# Altium schematic sheet Sheet1.SchDoc(Sheet1)
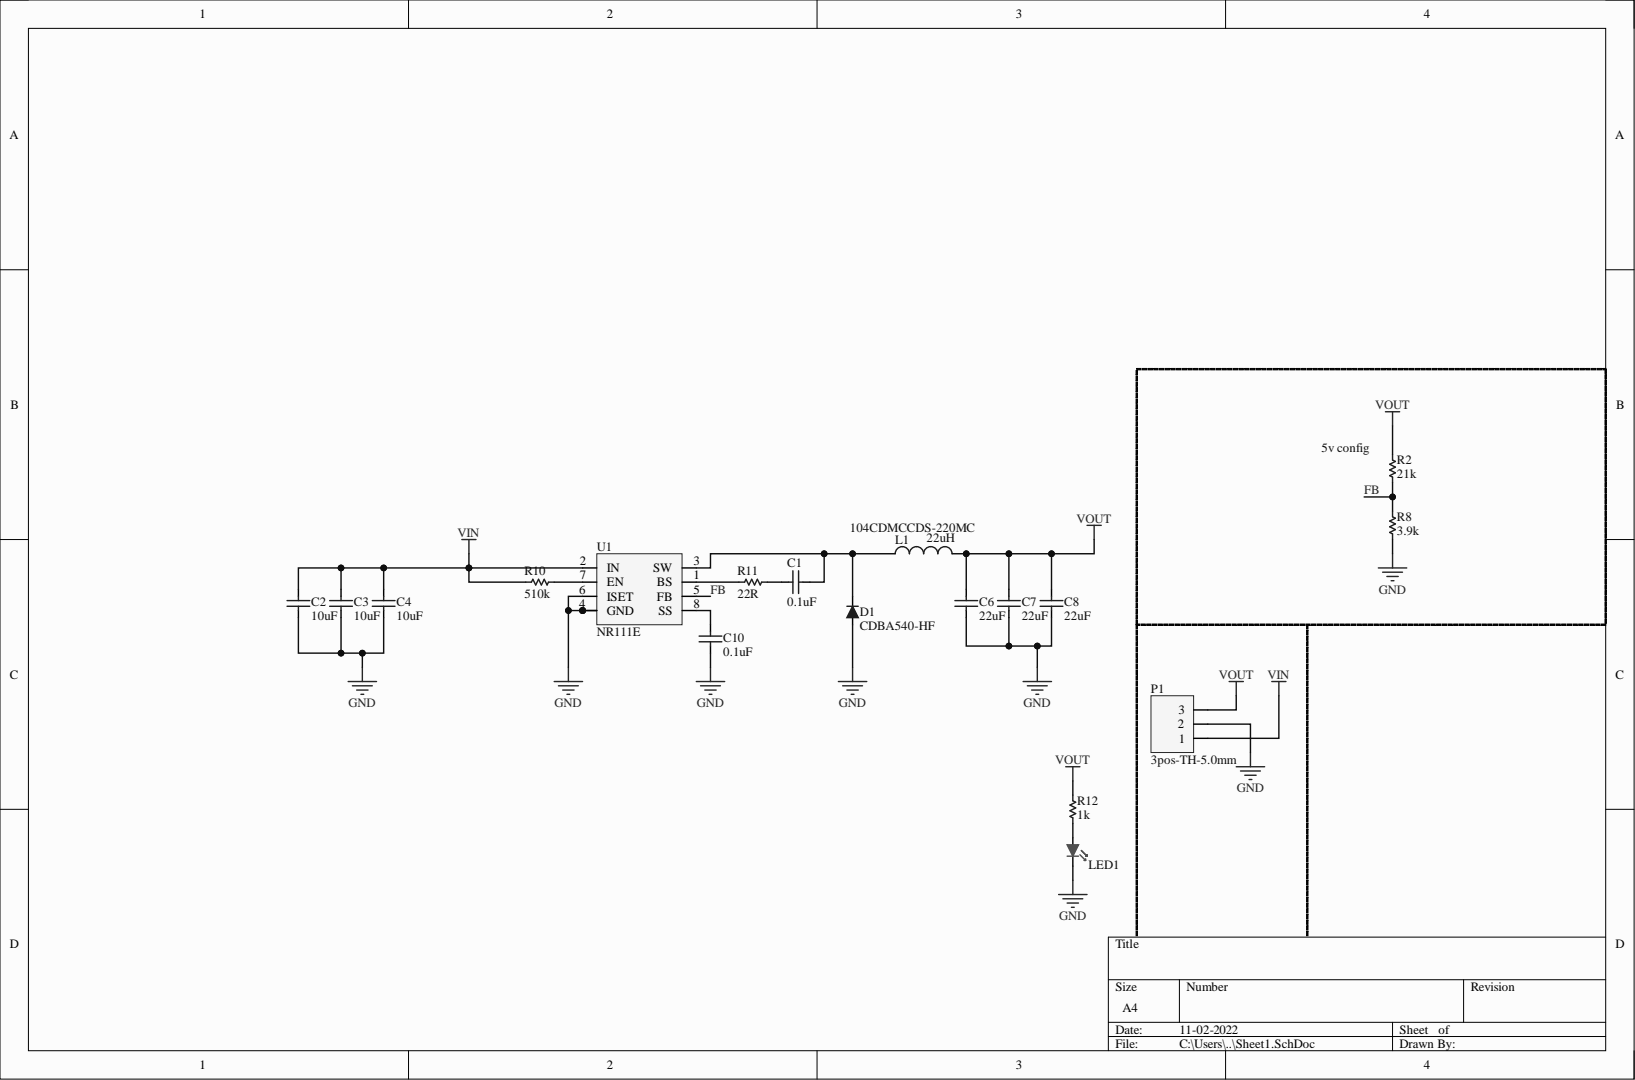
[diagram p0: Sheet1.SchDoc]

## Parts
C1  value 0.1uF  [CAPACITOR-0603]  (hidden comment: Capacitor-0603-0.1uF-50V-X7R)
C2  value 10uF  [CAPACITOR-0805]  (hidden comment: Capacitor-0805-10uF-35V-X5R)
C3  value 10uF  [CAPACITOR-0805]  (hidden comment: Capacitor-0805-10uF-35V-X5R)
C4  value 10uF  [CAPACITOR-0805]  (hidden comment: Capacitor-0805-10uF-35V-X5R)
C6  value 22uF  [CAPACITOR-0805]  (hidden comment: Capacitor-0805-22uF-16V-X5R)
C7  value 22uF  [CAPACITOR-0805]  (hidden comment: Capacitor-0805-22uF-16V-X5R)
C8  value 22uF  [CAPACITOR-0805]  (hidden comment: Capacitor-0805-22uF-16V-X5R)
C10  value 0.1uF  [CAPACITOR-0603]  (hidden comment: Capacitor-0603-0.1uF-50V-X7R)
D1  CDBA540-HF  [SMA/DO-214AC]
L1  104CDMCCDS-220MC  value 22uH  [Inductor_22UH 5A]
LED1  B1911USD-20D000114U1930  [LED-0603-2PIN]  (value not drawn)
P1  3pos-TH-5.0mm  [Side Connector 3pins]
R2  value 21k  [Resistor-0603]  (hidden comment: SMT resistor)
R8  value 3.9k  [Resistor-0603]  (hidden comment: SMT resistor)
R10  value 510k  [Resistor-0603]  (hidden comment: SMT resistor)
R11  value 22R  [Resistor-0603]  (hidden comment: SMT resistor)
R12  value 1k  [Resistor-0603]  (hidden comment: SMT resistor)
U1  NR111E  [SOIC-8-EXPOSED-PAD]

## Nets
GND: C2.1 C3.1 C4.1 C6.1 C7.1 C8.1 C10.2 D1.1 LED1.1 P1.2 R8.1 U1.4(GND) U1.6(ISET) U1.9
VIN: C2.2 C3.2 C4.2 P1.1 R10.1 U1.2(IN)
VOUT: C6.2 C7.2 C8.2 L1.2 P1.3 R2.2 R12.2
FB: R2.1 R8.2 U1.5(FB)
NetC1_1: C1.1 R11.2
NetC1_2: C1.2 D1.2 L1.1 U1.3(SW)
NetC10_1: C10.1 U1.8(SS)
NetLED1_2: LED1.2 R12.1
NetR10_2: R10.2 U1.7(EN)
NetR11_1: R11.1 U1.1(BS)

## Library data of the parts
C1 C10 = Capacitor-0603-0.1uF-50V-X7R: Precision: X7R-10%; Volt: 50V; Component Kind: Standard; Description: Capacitor-0603-0.1uF-50V-X7R; Library Name: Capacitors.SchLib; Mounted: Yes; Package / Case: Metric 1608, EIA 0603; Supplier 1: Digi-Key; Supplier 2: Digi-Key; Supplier 3: Digi-Key; Supplier 4: Digi-Key; Supplier Part Number 1: 1276-1935-2-ND; Supplier Part Number 2: 1276-1934-2-ND
C2-C4 = Capacitor-0805-10uF-35V-X5R: Precision: X5R-10%; Volt: 35V; Component Kind: Standard; Description: Capacitor-0805-10uF-35V-X5R; Library Name: Capacitors.SchLib; Mounted: Yes; Package / Case: Metric 2012 , EIA 0805; Supplier 1: Digi-Key; Supplier 2: Digi-Key; Supplier 3: Digi-Key; Supplier 4: Digi-Key; Supplier Part Number 1: 490-10515-1-ND
C6-C8 = Capacitor-0805-22uF-16V-X5R: Precision: X5R-10%; Volt: 16V; Component Kind: Standard; Description: Capacitor-0805-22uF-16V-X5R; Library Name: Capacitors.SchLib; Mounted: Yes; Package / Case: Metric 2012 , EIA 0805; Supplier 1: Digi-Key; Supplier 2: Digi-Key; Supplier 3: Digi-Key; Supplier 4: Digi-Key; Supplier Part Number 1: 1276-6780-1-ND
D1 = CDBA540-HF: DIODE SCHOTTKY 40V 5A SMA; Component Kind: Standard; Library Name: diodes.SchLib; Mounted: Yes; Package / Case: SMA; Supplier 1: Digi-Key; Supplier Part Number 1: 641-1707-1-ND; Volt: 40V
L1 = 104CDMCCDS-220MC: FIXED IND 22UH 5A 66 MOHM SMD; Precision: 20%; Component Kind: Standard; Current: 5A; Library Name: Inductors.SchLib; Mounted: Yes; Package / Case: SMD; Supplier 1: Digi-Key; Supplier Part Number 1: 308-2498-1-ND
LED1 = B1911USD-20D000114U1930: LED RED CLEAR 1608 SMD; Component Kind: Standard; Library Name: LEDs.SchLib; Mounted: Yes; Package / Case: 0603 (1608 Metric); Supplier 1: Digi-Key; Supplier Part Number 1: 3147-B1911USD-20D000114U1930CT-ND
P1 = 3pos-TH-5.0mm: 3pos-TH-5.0mm Screw Terminal; Component Kind: Standard; Library Name: ScrewTerminal.SchLib; Mounted: Yes; Package / Case: TH; Supplier 1: Digi-Key; Supplier Part Number 1: 277-1667-ND; Volt: N/A
R2 = Resistor0603-21k: Resistor; Precision: 1%; Watt: 0.1W; Component Kind: Standard; Library Name: Resistors.SchLib; Mounted: Yes; Package / Case: Metric 1608, EIA 0603; Supplier 1: Digi-Key; Supplier 2: Digi-Key; Supplier 3: Digi-Key; Supplier 4: Digi-Key; Supplier Part Number 1: 311-21.0KHRCT-ND
R8 = Resistor0603-3.9k: Resistor; Precision: 1%; Watt: 0.1W; Component Kind: Standard; Library Name: Resistors.SchLib; Mounted: Yes; Package / Case: Metric 1608, EIA 0603; Supplier 1: Digi-Key; Supplier 2: Digi-Key; Supplier 3: Digi-Key; Supplier 4: Digi-Key; Supplier Part Number 1: 311-3.90KHRCT-ND
R10 = Resistor0603-510k: Resistor; Precision: 1%; Watt: 0.1W; Component Kind: Standard; Library Name: Resistors.SchLib; Mounted: Yes; Package / Case: Metric 1608, EIA 0603; Supplier 1: Digi-Key; Supplier 2: Digi-Key; Supplier 3: Digi-Key; Supplier 4: Digi-Key; Supplier Part Number 1: P510KHCT-ND
R11 = Resistor0603-22R: Resistor; Precision: 1%; Watt: 0.1W; Component Kind: Standard; Library Name: Resistors.SchLib; Mounted: Yes; Package / Case: Metric 1608, EIA 0603; Supplier 1: Digi-Key; Supplier 2: Digi-Key; Supplier 3: Digi-Key; Supplier 4: Digi-Key; Supplier Part Number 1: RMCF0603FT22R0CT-ND
R12 = Resistor0603-1k: Resistor; Precision: 1%; Watt: 0.1W; Component Kind: Standard; Library Name: Resistors.SchLib; Mounted: Yes; Package / Case: Metric 1608, EIA 0603; Supplier 1: Digi-Key; Supplier 2: Digi-Key; Supplier 3: Digi-Key; Supplier 4: Digi-Key; Supplier Part Number 1: RMCF0603FT1K00TR-ND; Supplier Part Number 2: 311-1.00KHRTR-ND; Supplier Part Number 3: AG1307TR-ND
U1 = NR111E: IC REG BUCK ADJUSTABLE 4A 8SOIC; Grade: None; Component Kind: Standard; HelpURL: https://www.digikey.ca/en/products/detail/sanken/NR111E/4289131; Library Name: PMIC-VoltageRegulators-DCDCSwitchingRegulators.SchLib; Mounted: Yes; Package / Case: 8-SOIC-EP; Supplier 1: Digi-Key; Supplier Part Number 1: NR111ECT-ND
